# Altium schematic sheet SD.SchDoc(SD)
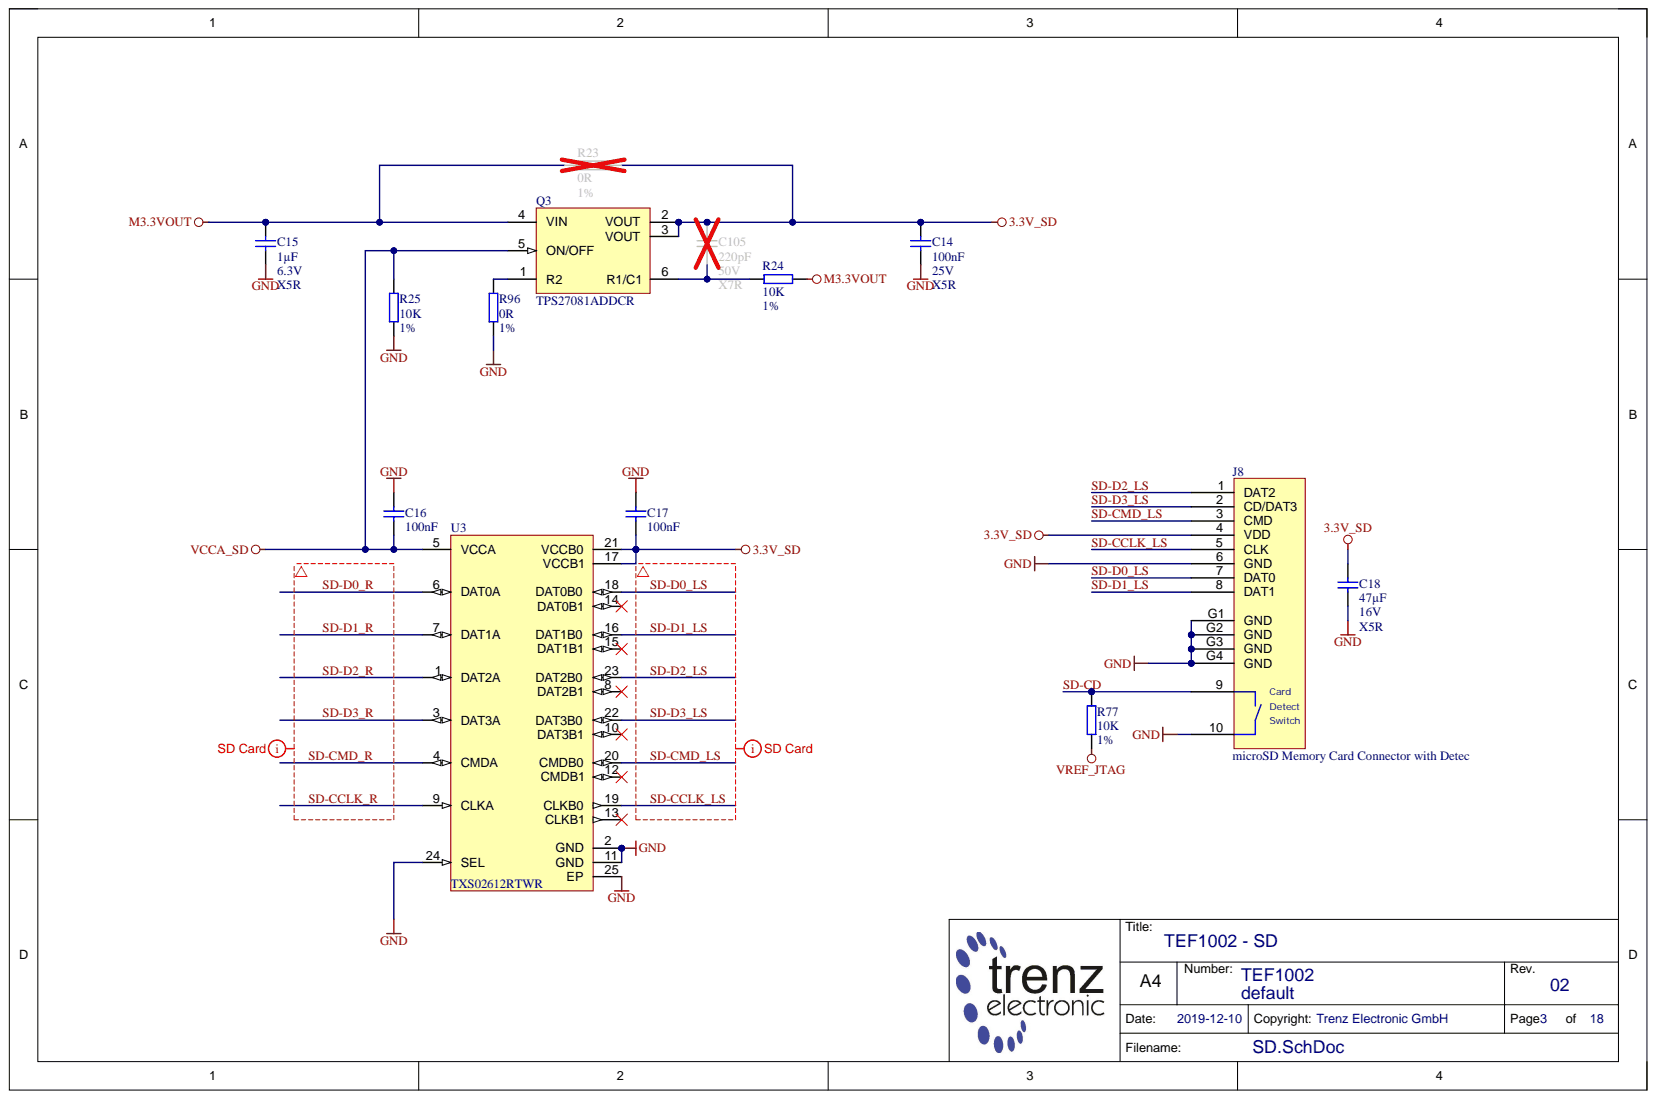
[diagram p0: SD.SchDoc]

## Parts
C14  100nF  Technology: X5R  Voltage: 25V  [CAPC1005X55N]
C15  1µF  Technology: X5R  Voltage: 6.3V  [CAPC1005X55N]
C16  100nF  [CAPC1005X55N]
C17  100nF  [CAPC1005X55N]
C18  47µF  Technology: X5R  Voltage: 16V  [CAPC3216X180N]
C105  220pF  Voltage: 50V  [CAPC1005X55N]
J8  microSD Memory Card Connector with Detec  Case: microSD  [104031-0811]
Q3  TPS27081ADDCR  [SOT95P280X110-6N]
R23  0R  [RESC2012X60N]
R24  10K  Tolerance: 1%  [RESC1005X40N]
R25  10K  Tolerance: 1%  [RESC1005X40N]
R77  10K  Tolerance: 1%  [RESC1005X40N]
R96  0R  Tolerance: 1%  [RESC1005X40N]
U3  TXS02612RTWR  [QFN50P400X400X80-25N-255]

## Nets
3.3V_SD: C14.2 C17.1 C18.2 C105.2 J8.4(VDD) Q3.2(VOUT) Q3.3(VOUT) R23.2 U3.17(VCCB1) U3.21(VCCB0)
GND: C14.1 C15.2 C16.2 C17.2 C18.1 J8.6(GND) J8.10 J8.G1(GND) J8.G2(GND) J8.G3(GND) J8.G4(GND) R25.2 R96.1 U3.2(GND) U3.11(GND) U3.24(SEL) U3.25(EP)
M3.3VOUT: C15.1 Q3.4(VIN) R23.1 R24.2
VCCA_SD: C16.1 Q3.5(ON/OFF) R25.1 U3.5(VCCA)
VREF_JTAG: R77.2
SD-CCLK_LS: J8.5(CLK) U3.19(CLKB0)
SD-CCLK_R: U3.9(CLKA)
SD-CD: J8.9 R77.1
SD-CMD_LS: J8.3(CMD) U3.20(CMDB0)
SD-CMD_R: U3.4(CMDA)
SD-D0_LS: J8.7(DAT0) U3.18(DAT0B0)
SD-D0_R: U3.6(DAT0A)
SD-D1_LS: J8.8(DAT1) U3.16(DAT1B0)
SD-D1_R: U3.7(DAT1A)
SD-D2_LS: J8.1(DAT2) U3.23(DAT2B0)
SD-D2_R: U3.1(DAT2A)
SD-D3_LS: J8.2(CD/DAT3) U3.22(DAT3B0)
SD-D3_R: U3.3(DAT3A)
NetC105_1: C105.1 Q3.6(R1/C1) R24.1
NetQ3_1: Q3.1(R2) R96.2

Not connected: U3 8(DAT2B1) 10(DAT3B1) 12(CMDB1) 13(CLKB1) 14(DAT0B1) 15(DAT1B1)

## Library data of the parts
C14 C16 C17 = 22472: KON 100nF 25V X5R ±10% SMD BF 1005 (0402); Database Table Name: C_1005_0402; Mixed: SMT; Preferred: Yes; Temperature-Range: -55/+85; Value: 100n; AN: 22472; Case: 1005 (0402); Component Kind: Standard; Library Name: TE.DbLib
  C16 C17: Technology: X5R; Voltage: 25V
C15 = 23453: KON 1µF 6.3V X5R 10% SMD BF 1005 (0402); Database Table Name: C_1005_0402; Mixed: SMT; Preferred: Yes; Temperature-Range: -55/+85; Value: 1u; AN: 23453; Case: 1005 (0402); Component Kind: Standard; Library Name: TE.DbLib; Tolerance: 10%
C18 = 24955: KON 47µF 16V  X5R 20% SMD BF 3216 (1206); Database Table Name: C_3216_1206; Mixed: SMT; Preferred: Yes; Temperature-Range: -55/+85; Value: 47u; AN: 24955; Case: 3216 (1206); Component Kind: Standard; Library Name: TE.DbLib; Tolerance: 20%
C105 = 24207: KON 220pF 50V X7R 10%  SMD BF 1005 (0402); Database Table Name: C_1005_0402; Mixed: SMT; Preferred: Yes; Temperature-Range: -55/+125; Value: 220p; AN: 24207; Case: 1005 (0402); Component Kind: Standard; Library Name: TE.DbLib; Technology: X7R; Tolerance: 10%
J8 = 26322: microSD Memory Card Connector with Detect Switch; Database Table Name: SOCKET; Mixed: SMT; Temperature-Range: -25/+85; Trenz-Stock: Yes; AN: 26322; Component Kind: Standard; H-ART: 104031-0811; Library Name: TE.DbLib; Storage-Temperature: -10/+60; HelpURL: B:\AD\adlib\datasheet\104031-0811
Q3 = 29262: Database Table Name: SWITCH; Mixed: SMT; Temperature-Range: -40/+85; AN: 29262; Case: SOT23-6; Component Kind: Standard; Description: TPS27081ADDCR; H-ART: TPS27081ADDCR; Library Name: TE.DbLib; HelpURL: b:\AD\adlib\datasheet\TPS27081A\
R23 = 23415: WID SMD 0R 0.125W 2A Brücke; Database Table Name: R_2012_0805; Mixed: SMT; Preferred: Yes; Tolerance: 1%; Value: 0; AN: 23415; Case: 2012 (0805); Component Kind: Standard; Library Name: TE.DbLib; Technology: TK100
R24 R25 R77 = 22750: WID SMD 10K 1% 0,063W BF 1005 (0402); Database Table Name: R_1005_0402; H-ART: RC0402FR-0710KL; Mixed: SMT; Preferred: Yes; AN: 22750; Case: 1005 (0402); Component Kind: Standard; Library Name: TE.DbLib; Technology: TK100; Value: 10K
R96 = 22738: WID SMD 0R  BF 1005 (0402); Database Table Name: R_1005_0402; H-ART: RC0402JR-070RL; Mixed: SMT; Preferred: Yes; Value: 0; AN: 22738; Case: 1005 (0402); Component Kind: Standard; Library Name: TE.DbLib; Technology: TK100
U3 = 24904: Database Table Name: IF; Mixed: SMT; Trenz-Stock: Yes; AN: 24904; Case: QFN24; Component Kind: Standard; Description: TXS02612RTWR; H-ART: TXS02612RTWR; Library Name: TE.DbLib; HelpURL: B:\AD\adlib\datasheet\TXS02612
